# Altium schematic sheet ESP32-C3Mini-CH340.SchDoc
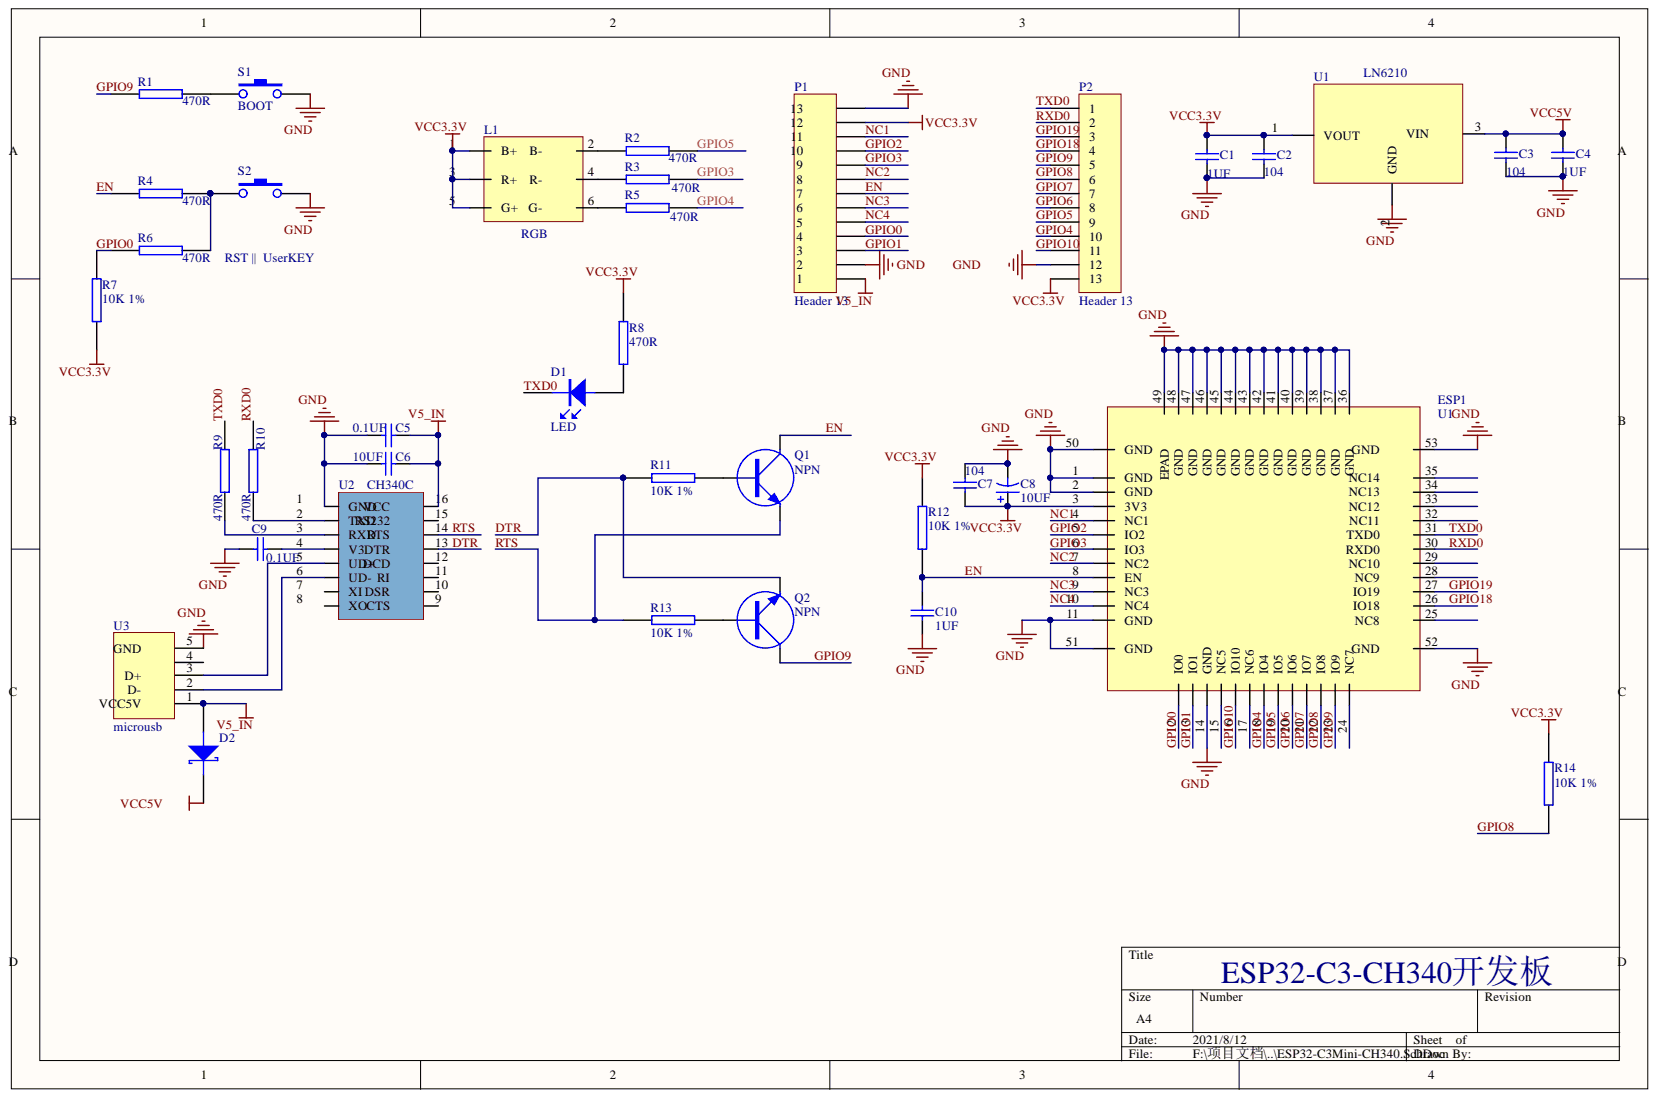
[diagram p0: ESP32-C3Mini-CH340.SchDoc]

## Parts
C1  1UF  [C0402]
C2  104  [C0402]
C3  104  [C0402]
C4  1UF  [C0402]
C5  value 0.1UF  [C0402]  (hidden comment: Cap)
C6  value 10UF  [1206]  (hidden comment: Cap)
C7  104  [C0402]
C8  10UF  [C0402]
C9  value 0.1UF  [C0402]  (hidden comment: Cap)
C10  1UF  [C0402]
D1  LED  [0603D]
D2  (no value)  [D0603]
ESP1  U1  [esp32-c3 mini]
L1  RGB  [5050]
P1  Header 13  [HDR1X13]
P2  Header 13  [HDR1X13]
Q1  NPN  [SOT-23]
Q2  NPN  [SOT-23]
R1  470R  [R0402]
R2  470R  [R0402]
R3  470R  [R0402]
R4  470R  [R0402]
R5  470R  [R0402]
R6  470R  [R0402]
R7  10K 1%  [R0402]
R8  470R  [R0402]
R9  470R  [R0402]
R10  470R  [R0402]
R11  10K 1%  [R0402]
R12  10K 1%  [R0402]
R13  10K 1%  [R0402]
R14  10K 1%  [R0402]
S1  BOOT  [button]
S2  RST ||  UserKEY  [button]
U1  LN6210  [LN6210]
U2  CH340C  [SO-16_M]
U3  microusb  [USB_T]

## Nets
GND: C1.2 C2.1 C3.2 C4.2 C5.1 C6.1 C7.2 C8.2 C9.1 C10.1 ESP1.1(GND) ESP1.2(GND) ESP1.11(GND) ESP1.14(GND) ESP1.36 ESP1.37 ESP1.38 ESP1.39 ESP1.40 ESP1.41 ESP1.42 ESP1.43 ESP1.44 ESP1.45 ESP1.46 ESP1.47 ESP1.48 ESP1.49 ESP1.50(GND) ESP1.51(GND) ESP1.52 ESP1.53 P1.2 P1.13 P2.12 S1.2 S2.2 U1.2 U2.1(GND) U3.5
V5_IN: C5.2 C6.2 D2.1 P1.1 U2.16 U3.1
VCC3.3V: C1.1 C2.2 C7.1 C8.1 ESP1.3(3V3) L1.1(B+) L1.3(R+) L1.5(G+) P1.12 P2.13 R7.1 R8.2 R12.2 R14.2 U1.1
VCC5V: C3.1 C4.1 D2.2 U1.3
DTR: Q2.1 R11.1 U2.13
EN: C10.2 ESP1.8(EN) P1.7 Q1.3 R4.2 R12.1
GPIO0: ESP1.12(IO0) P1.4 R6.2 R7.2
GPIO1: ESP1.13(IO1) P1.3
GPIO2: ESP1.5(IO2) P1.10
GPIO3: ESP1.6(IO3) P1.9 R3.1
GPIO4: ESP1.18(IO4) P2.10 R5.1
GPIO5: ESP1.19(IO5) P2.9 R2.1
GPIO6: ESP1.20(IO6) P2.8
GPIO7: ESP1.21(IO7) P2.7
GPIO8: ESP1.22(IO8) P2.6 R14.1
GPIO9: ESP1.23(IO9) P2.5 Q2.3 R1.2
GPIO10: ESP1.16(IO10) P2.11
GPIO18: ESP1.26 P2.4
GPIO19: ESP1.27 P2.3
NC1: ESP1.4(NC1) P1.11
NC2: ESP1.7(NC2) P1.8
NC3: ESP1.9(NC3) P1.6
NC4: ESP1.10(NC4) P1.5
RTS: Q1.1 R13.1 U2.14
RXD0: ESP1.30 P2.2 R10.2
TXD0: D1.K ESP1.31 P2.1 R9.2
NetC9_2: C9.2 U2.4(V3_DTR)
NetD1_A: D1.A R8.1
NetL1_2: L1.2 R2.2
NetL1_4: L1.4 R3.2
NetL1_6: L1.6 R5.2
NetQ1_2: Q1.2 R11.2
NetQ2_2: Q2.2 R13.2
NetR1_1: R1.1 S1.1
NetR4_1: R4.1 R6.1 S2.1
NetR9_1: R9.1 U2.3(RXD)
NetR10_1: R10.1 U2.2(TXD)
NetU2_5: U2.5(UD+) U3.3
NetU2_6: U2.6(UD-) U3.2

Not connected: ESP1 15(NC5) 17(NC6) 24(NC7) 25 28 29 32 33 34 35; U2 7(XI_DSR) 8(XO_CTS) 9 10 11 12 15; U3 4

## Library data of the parts
C1 C4 = Cap: Capacitor; Value: 104; Component Kind: Standard; LatestRevisionDate: 17-Jul-2002; LatestRevisionNote: Re-released for DXP Platform.; Library Name: Miscellaneous Devices.IntLib; PackageDescription: Radial Cap, Thru-Hole; 2 Leads; 0.3 in Pin Spacing; PackageReference: RAD-0.3; Published: 8-Jun-2000; Signal Integrity: Cap; Simulation: CAP; Publisher: Altium Limited
C2 C3 C5 C6 C9 C10 = Cap: Capacitor; Component Kind: Standard; LatestRevisionDate: 17-Jul-2002; LatestRevisionNote: Re-released for DXP Platform.; Library Name: Miscellaneous Devices.IntLib; PackageDescription: Radial Cap, Thru-Hole; 2 Leads; 0.3 in Pin Spacing; PackageReference: RAD-0.3; Published: 8-Jun-2000; Signal Integrity: Cap; Simulation: CAP; Publisher: Altium Limited
  C2 C3: Value: 104
  C10: Value: 1UF
C7 = Cap: Capacitor; Value: 0.1UF; Component Kind: Standard; LatestRevisionDate: 17-Jul-2002; LatestRevisionNote: Re-released for DXP Platform.; Library Name: Miscellaneous Devices.IntLib; PackageDescription: Radial Cap, Thru-Hole; 2 Leads; 0.3 in Pin Spacing; PackageReference: RAD-0.3; Published: 8-Jun-2000; Signal Integrity: Cap; Simulation: CAP; Publisher: Altium Limited
C8 = Cap Pol1: Polarized Capacitor (Radial); Component Kind: Standard; LatestRevisionDate: 17-Jul-2002; LatestRevisionNote: Re-released for DXP Platform.; Library Name: Miscellaneous Devices.IntLib; PackageDescription: Polarized Capacitor (Radial); 2 Leads; PackageReference: RB7.6-15; Published: 8-Jun-2000; Signal Integrity: Cap Pol; Simulation: CAP; Value: 10UF; Publisher: Altium Limited
D2 = DIODE SCHOTTKY: Schottky Diode; Component Kind: Standard; Library Name: Miscellaneous Devices.SCHLIB
ESP1 = ESP32-C3-Mini: Component Kind: Standard; Library Name: ESP32-C3开发板.SchLib
P1 P2 = Header 13: Header, 13-Pin; Component Kind: Standard; LatestRevisionDate: 17-Jul-2002; LatestRevisionNote: Re-released for DXP Platform.; Library Name: Miscellaneous Connectors.IntLib; Signal Integrity: Connector; Publisher: Altium Limited
Q1 Q2 = NPN: NPN Transistor; Component Kind: Standard; Library Name: Miscellaneous Devices.SCHLIB
R1-R14 = RES2: Component Kind: Standard; Library Name: 常用1.SchLib
S1 S2 = SW-PB: Component Kind: Standard; Library Name: Miscellaneous Devices.SCHLIB
U2 = CH340: Component Kind: Standard; Library Name: TLC521（光耦）.SchLib
D1 = LED: Component Kind: Standard; Library Name: Miscellaneous Devices.SCHLIB
L1 = RGB: Component Kind: Standard; Library Name: lib-esp32.SchLib
U1 = LN6210: Component Kind: Standard; Library Name: ESP32-C3开发板.SchLib
U3 = microusb: Component Kind: Standard; Library Name: GY32-B1.SchLib
